# Altium schematic sheet Sheet1.SchDoc(Sheet1)
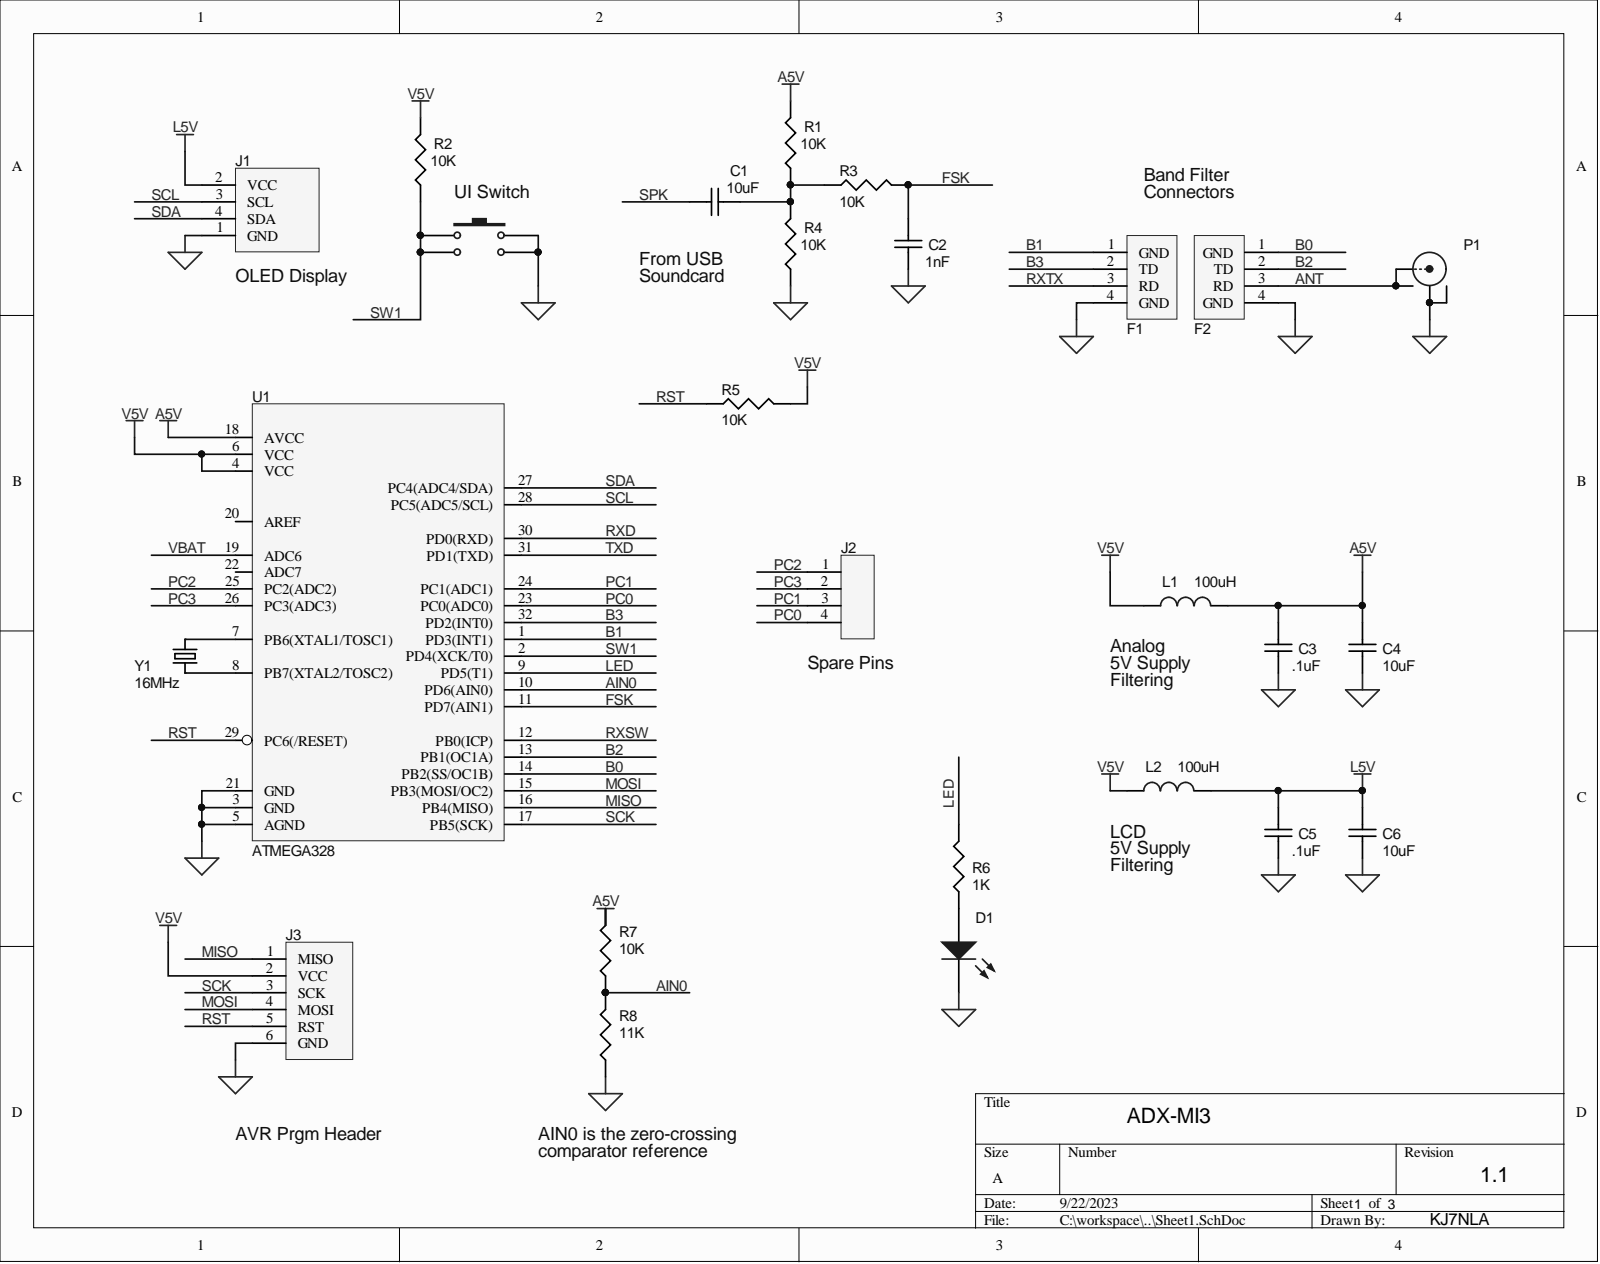
[diagram p0: Sheet1.SchDoc]

## Parts
C1  10uF  [3-0603]
C2  1nF  [3-0603]
C3  .1uF  [3-0603]
C4  10uF  [3-0603]
C5  .1uF  [3-0603]
C6  10uF  [3-0603]
D1  LED  [3-0603]  (value not drawn)
F1  LPF Module  [RFMODULE-A]  (value not drawn)
F2  LPF Module  [RFMODULE-A]  (value not drawn)
J1  OLED Display  [CONN1X4A]
J2  Header-4  [CONN2X2A]  (value not drawn)
J3  Header AVR  [CONN2X3]  (value not drawn)
L1  100uH  [6-0805]
L2  100uH  [6-0805]
P1  BNC  [CONN-BNC-2]  (value not drawn)
R1  value 10K  [3-0603]  (hidden comment: 10.0K)
R2  value 10K  [3-0603]  (hidden comment: 10.0K)
R3  value 10K  [3-0603]  (hidden comment: 10.0K)
R4  value 10K  [3-0603]  (hidden comment: 10.0K)
R5  value 10K  [3-0603]  (hidden comment: 10.0K)
R6  1K  [3-0603]
R7  value 10K  [3-0603]  (hidden comment: 10.0K)
R8  11K  [3-0603]
S1  Push Button  [PB1]  (value not drawn)
U1  ATMEGA328  [ATMEG328]
Y1  16MHz  [Xtal_SMT1]

## Nets
A5V: C3.2 C4.2 L1.2 R1.2 R7.2 U1.18(AVCC)
GND: C2.1 C3.1 C4.1 C5.1 C6.1 D1.2 F1.4(GND) F2.4(GND) J1.1(GND) J3.6(GND) P1.2 P1.6 R4.1 R8.1 S1.3 S1.4 U1.3(GND) U1.5(AGND) U1.21(GND)
L5V: C5.2 C6.2 J1.2(VCC) L2.2
V5V: J3.2(VCC) L1.1 L2.1 R2.2 R5.2 U1.4(VCC) U1.6(VCC)
AIN0: R7.1 R8.2 U1.10(PD6(AIN0))
ANT: F2.3(RD) P1.1 P1.5
B0: F2.1(GND) U1.14(PB2(SS/OC1B))
B1: F1.1(GND) U1.1(PD3(INT1))
B2: F2.2(TD) U1.13(PB1(OC1A))
B3: F1.2(TD) U1.32(PD2(INT0))
FSK: C2.2 R3.2 U1.11(PD7(AIN1))
LED: R6.2 U1.9(PD5(T1))
MISO: J3.1(MISO) U1.16(PB4(MISO))
MOSI: J3.4(MOSI) U1.15(PB3(MOSI/OC2))
PC0: J2.4 U1.23(PC0(ADC0))
PC1: J2.3 U1.24(PC1(ADC1))
PC2: J2.1 U1.25(PC2(ADC2))
PC3: J2.2 U1.26(PC3(ADC3))
RST: J3.5(RST) R5.1 U1.29(PC6(/RESET))
RXD: U1.30(PD0(RXD))
RXSW: U1.12(PB0(ICP))
RXTX: F1.3(RD)
SCK: J3.3(SCK) U1.17(PB5(SCK))
SCL: J1.3(SCL) U1.28(PC5(ADC5/SCL))
SDA: J1.4(SDA) U1.27(PC4(ADC4/SDA))
SPK: C1.1
SW1: R2.1 S1.1 S1.2 U1.2(PD4(XCK/T0))
TXD: U1.31(PD1(TXD))
VBAT: U1.19(ADC6)
NetC1_2: C1.2 R1.1 R3.1 R4.2
NetD1_1: D1.1 R6.1
NetU1_7: U1.7(PB6(XTAL1/TOSC1)) Y1.3
NetU1_8: U1.8(PB7(XTAL2/TOSC2)) Y1.1

Not connected: U1 20(AREF) 22(ADC7)

## Library data of the parts
C1-C6 = Cap: Supplier Part Number 1: NA; Supplier 1: Digi-Key; Component Kind: Standard; Library Name: usdx.SchLib
  C1 C4 C6: Value: 10uF; JLCC Part Number: C344022
  C2: Value: 1nF; JLCC Part Number: C106246
  C3 C5: Value: .1uF; JLCC Part Number: C113803
D1 = LED1: Supplier Part Number 1: NA; Supplier 1: Digi-Key; Library Name: Miscellaneous Devices.IntLib; JLCC Part Number: C434419; Component Kind: Standard
F1 F2 = LPF-A: Supplier Part Number 1: NA; Supplier 1: NA; JLCC Part Number: NA; Component Kind: Standard; Library Name: usdx.SchLib
J1 = OLED: Supplier Part Number 1: NA; Supplier 1: Amazon; JLCC Part Number: NA; Component Kind: Standard; Library Name: usdx.SchLib
L1 L2 = Inductor: Value: 100uH; Supplier Part Number 1: NA; Supplier 1: Digi-Key; JLCC Part Number: C223180; Component Kind: Standard; Library Name: usdx.SchLib
R1-R8 = Resistor: Supplier Part Number 1: NA; Supplier 1: Digi-Key; Component Kind: Standard; Library Name: usdx.SchLib
  R1-R5 R7: JLCC Part Number: C191318
  R6: Value: 1K; JLCC Part Number: C14676
  R8: Value: 11K; JLCC Part Number: C336851
S1 = SW-PB: Supplier Part Number 1: NA; Supplier 1: Digi-Key; JLCC Part Number: C221880; Component Kind: Standard; Library Name: usdx.SchLib
U1 = ATMEGA328-SMT: Supplier Part Number 1: NA; Supplier 1: Digi-Key; JLCC Part Number: C14877; Component Kind: Standard; Library Name: usdx.SchLib
Y1 = XTAL-SMT: Value: 16MHz; Supplier Part Number 1: NA; Supplier 1: Digi-Key; JLCC Part Number: C13738; Component Kind: Standard; Library Name: usdx.SchLib
J2 = Header-4: Supplier Part Number 1: NA; Supplier 1: NA; JLCC Part Number: NA; Component Kind: Standard; Library Name: usdx.SchLib
J3 = Header AVR: Supplier Part Number 1: NA; Supplier 1: Digi-Key; JLCC Part Number: NA; Component Kind: Standard; Library Name: usdx.SchLib
P1 = BNC: Supplier Part NUmber 1: NA; Supplier 1: Digi-Key; JLCC Part Number: NA; Component Kind: Standard; Library Name: usdx.SchLib
